# Altium schematic sheet Logic.SchDoc(Logic)
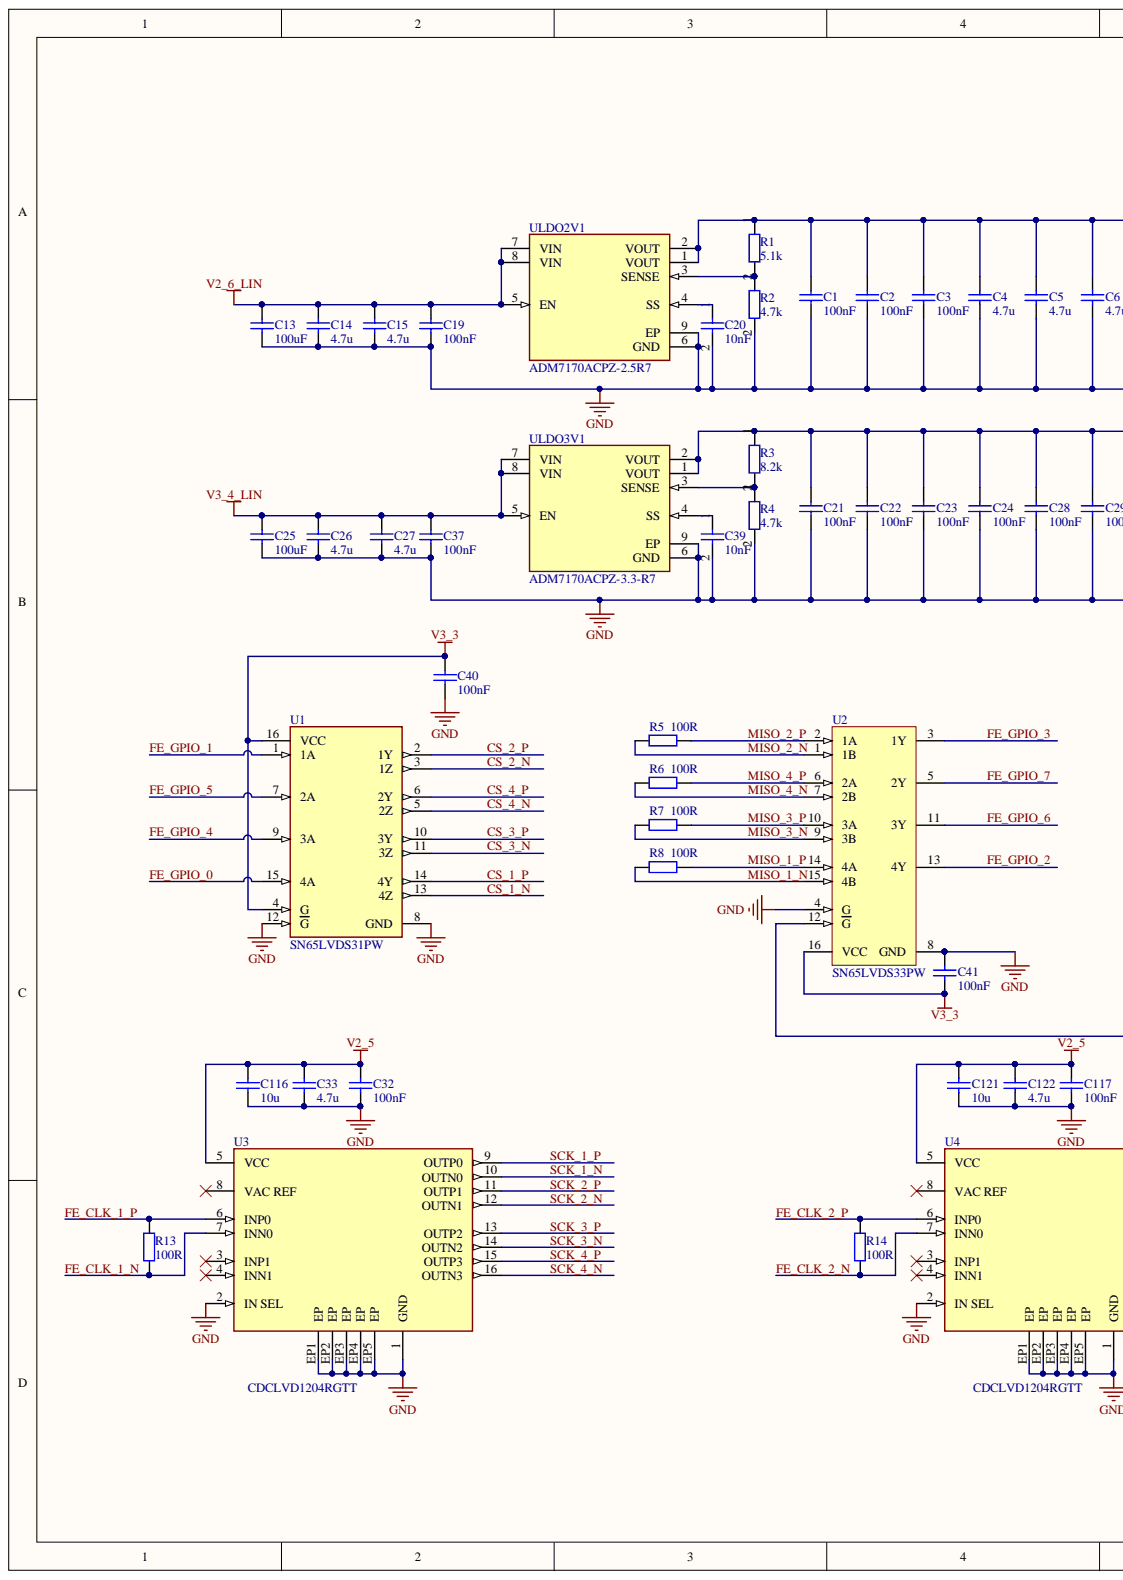
[diagram p0: Logic.SchDoc, left]
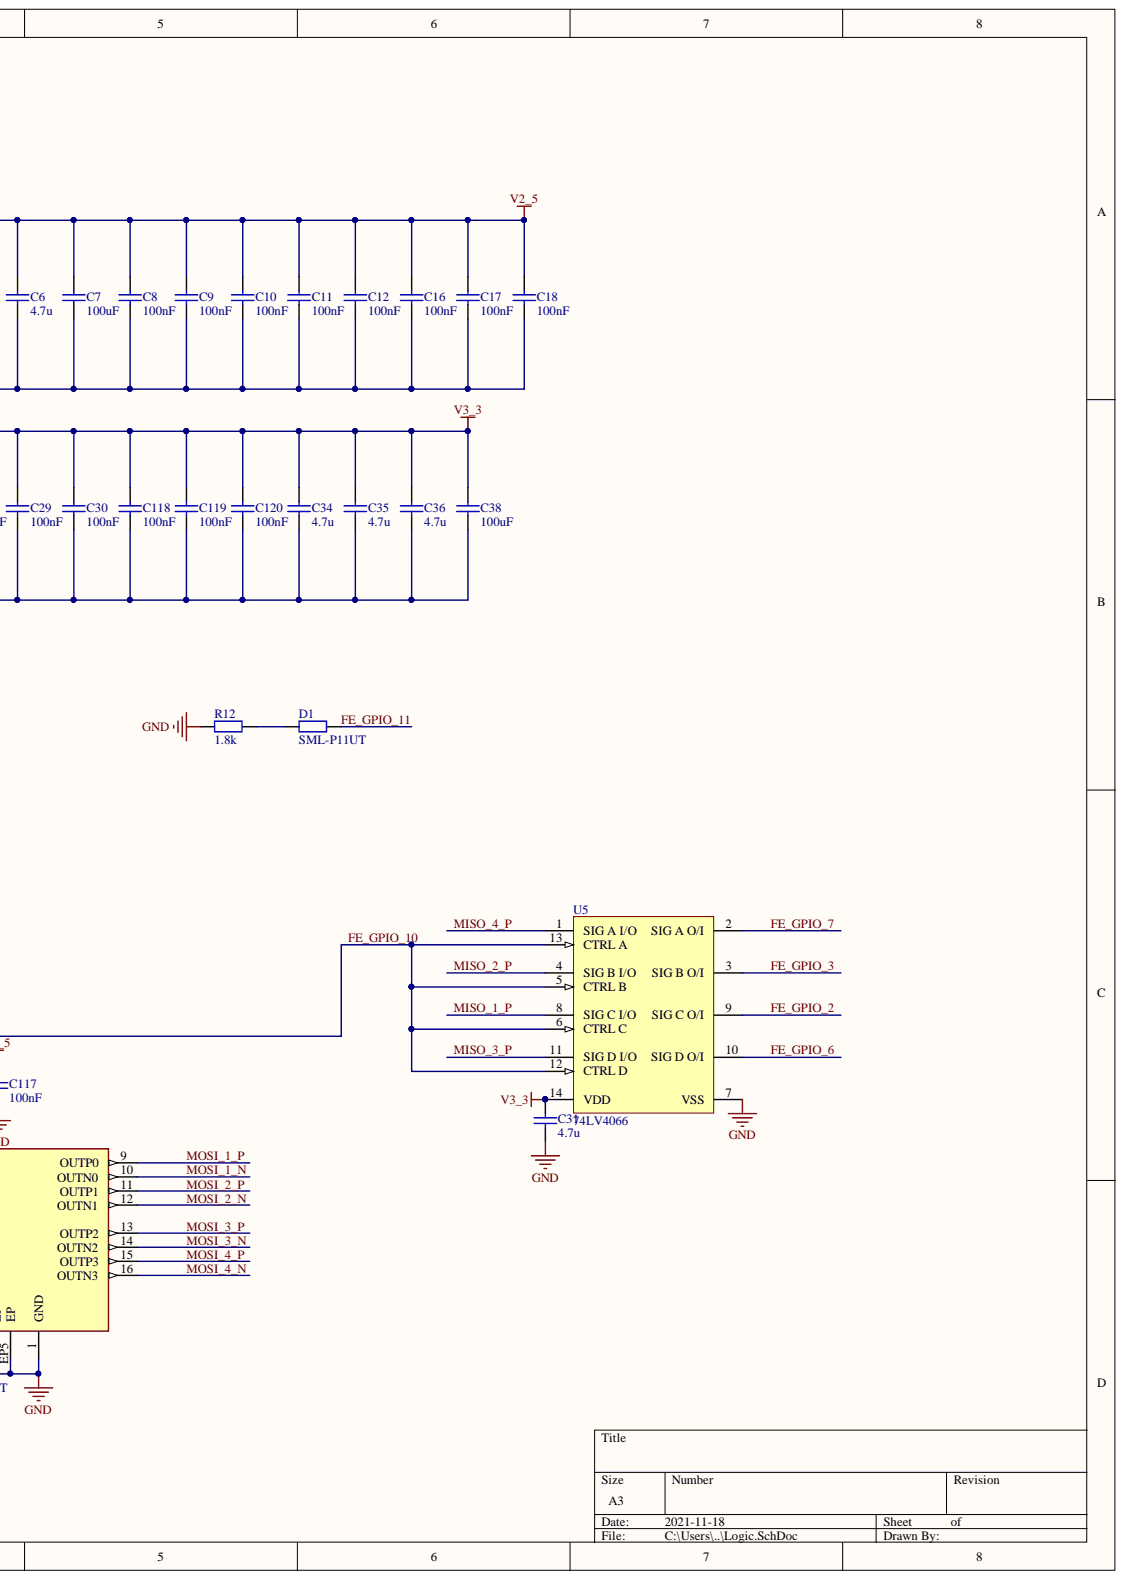
[diagram p1: Logic.SchDoc, right]

## Parts
C1  value 100nF  [C-0402-C]  (hidden comment: Cap)
C2  value 100nF  [C-0402-C]  (hidden comment: Cap)
C3  value 100nF  [C-0402-C]  (hidden comment: Cap)
C4  value 4.7u  [C-0402-C]  (hidden comment: Cap)
C5  value 4.7u  [C-0402-C]  (hidden comment: Cap)
C6  value 4.7u  [C-0402-C]  (hidden comment: Cap)
C7  value 100uF  [C-1206]  (hidden comment: Cap)
C8  value 100nF  [C-0402-C]  (hidden comment: Cap)
C9  value 100nF  [C-0402-C]  (hidden comment: Cap)
C10  value 100nF  [C-0402-C]  (hidden comment: Cap)
C11  value 100nF  [C-0402-C]  (hidden comment: Cap)
C12  value 100nF  [C-0402-C]  (hidden comment: Cap)
C13  value 100uF  [C-1206]  (hidden comment: Cap)
C14  value 4.7u  [C-0402-C]  (hidden comment: Cap)
C15  value 4.7u  [C-0402-C]  (hidden comment: Cap)
C16  value 100nF  [C-0402-C]  (hidden comment: Cap)
C17  value 100nF  [C-0402-C]  (hidden comment: Cap)
C18  value 100nF  [C-0402-C]  (hidden comment: Cap)
C19  value 100nF  [C-0402-C]  (hidden comment: Cap)
C20  value 10nF  [C-0402-C]  (hidden comment: Cap)
C21  value 100nF  [C-0402-C]  (hidden comment: Cap)
C22  value 100nF  [C-0402-C]  (hidden comment: Cap)
C23  value 100nF  [C-0402-C]  (hidden comment: Cap)
C24  value 100nF  [C-0402-C]  (hidden comment: Cap)
C25  value 100uF  [C-1206]  (hidden comment: Cap)
C26  value 4.7u  [C-0402-C]  (hidden comment: Cap)
C27  value 4.7u  [C-0402-C]  (hidden comment: Cap)
C28  value 100nF  [C-0402-C]  (hidden comment: Cap)
C29  value 100nF  [C-0402-C]  (hidden comment: Cap)
C30  value 100nF  [C-0402-C]  (hidden comment: Cap)
C31  value 4.7u  [C-0402-C]  (hidden comment: Cap)
C32  value 100nF  [C-0402-C]  (hidden comment: Cap)
C33  value 4.7u  [C-0402-C]  (hidden comment: Cap)
C34  value 4.7u  [C-0402-C]  (hidden comment: Cap)
C35  value 4.7u  [C-0402-C]  (hidden comment: Cap)
C36  value 4.7u  [C-0402-C]  (hidden comment: Cap)
C37  value 100nF  [C-0402-C]  (hidden comment: Cap)
C38  value 100uF  [C-1206]  (hidden comment: Cap)
C39  value 10nF  [C-0402-C]  (hidden comment: Cap)
C40  value 100nF  [C-0402-C]  (hidden comment: Cap)
C41  value 100nF  [C-0402-C]  (hidden comment: Cap)
C116  value 10u  [C-0805]  (hidden comment: Cap)
C117  value 100nF  [C-0402-C]  (hidden comment: Cap)
C118  value 100nF  [C-0402-C]  (hidden comment: Cap)
C119  value 100nF  [C-0402-C]  (hidden comment: Cap)
C120  value 100nF  [C-0402-C]  (hidden comment: Cap)
C121  value 10u  [C-0805]  (hidden comment: Cap)
C122  value 4.7u  [C-0402-C]  (hidden comment: Cap)
D1  value SML-P11UT  [D-0402]  (hidden comment: Res2)
R1  value 5.1k  [R-0402-C]  (hidden comment: Res2)
R2  value 4.7k  [R-0402-C]  (hidden comment: Res2)
R3  value 8.2k  [R-0402-C]  (hidden comment: Res2)
R4  value 4.7k  [R-0402-C]  (hidden comment: Res2)
R5  value 100R  [R-0402-C]  (hidden comment: Res2)
R6  value 100R  [R-0402-C]  (hidden comment: Res2)
R7  value 100R  [R-0402-C]  (hidden comment: Res2)
R8  value 100R  [R-0402-C]  (hidden comment: Res2)
R12  value 1.8k  [R-0402-C]  (hidden comment: Res2)
R13  value 100R  [R-0402-C]  (hidden comment: Res2)
R14  value 100R  [R-0402-C]  (hidden comment: Res2)
U1  SN65LVDS31PW  [TI-TSSOP16PW-RM065]
U2  SN65LVDS33PW  [TI-TSSOP16PW-RM065]
U3  CDCLVD1204RGTT  [TI-SPVQFN-N16]
U4  CDCLVD1204RGTT  [TI-SPVQFN-N16]
U5  74LV4066  [D0014A_N]
ULDO2V1  ADM7170ACPZ-2.5R7  [LFCSP_WD-8]
ULDO3V1  ADM7170ACPZ-3.3-R7  [LFCSP_WD-8]

## Nets
GND: C1.1 C2.1 C3.1 C4.2 C5.2 C6.2 C7.2 C8.1 C9.1 C10.1 C11.1 C12.1 C13.2 C14.2 C15.2 C16.1 C17.1 C18.1 C19.1 C20.2 C21.1 C22.1 C23.1 C24.1 C25.2 C26.2 C27.2 C28.1 C29.1 C30.1 C31.2 C32.2 C33.2 C34.2 C35.2 C36.2 C37.1 C38.2 C39.2 C40.1 C41.1 C116.2 C117.2 C118.1 C119.1 C120.1 C121.2 C122.2 R2.2 R4.2 R12.1 U1.8(GND) U1.12(G) U2.4(G) U2.8(GND) U3.1(GND) U3.2(IN_SEL) U3.EP1(EP) U3.EP2(EP) U3.EP3(EP) U3.EP4(EP) U3.EP5(EP) U4.1(GND) U4.2(IN_SEL) U4.EP1(EP) U4.EP2(EP) U4.EP3(EP) U4.EP4(EP) U4.EP5(EP) U5.7(VSS) ULDO2V1.6(GND) ULDO2V1.9(EP) ULDO3V1.6(GND) ULDO3V1.9(EP)
V2_5: C1.2 C2.2 C3.2 C4.1 C5.1 C6.1 C7.1 C8.2 C9.2 C10.2 C11.2 C12.2 C16.2 C17.2 C18.2 C32.1 C33.1 C116.1 C117.1 C121.1 C122.1 R1.1 U3.5(VCC) U4.5(VCC) ULDO2V1.1(VOUT) ULDO2V1.2(VOUT)
V2_6_LIN: C13.1 C14.1 C15.1 C19.2 ULDO2V1.5(EN) ULDO2V1.7(VIN) ULDO2V1.8(VIN)
V3_3: C21.2 C22.2 C23.2 C24.2 C28.2 C29.2 C30.2 C31.1 C34.1 C35.1 C36.1 C38.1 C40.2 C41.2 C118.2 C119.2 C120.2 R3.1 U1.4(G) U1.16(VCC) U2.16(VCC) U5.14(VDD) ULDO3V1.1(VOUT) ULDO3V1.2(VOUT)
V3_4_LIN: C25.1 C26.1 C27.1 C37.2 ULDO3V1.5(EN) ULDO3V1.7(VIN) ULDO3V1.8(VIN)
CS_1_N: U1.13(4Z)
CS_1_P: U1.14(4Y)
CS_2_N: U1.3(1Z)
CS_2_P: U1.2(1Y)
CS_3_N: U1.11(3Z)
CS_3_P: U1.10(3Y)
CS_4_N: U1.5(2Z)
CS_4_P: U1.6(2Y)
FE_CLK_1_N: R13.2 U3.7(INN0)
FE_CLK_1_P: R13.1 U3.6(INP0)
FE_CLK_2_N: R14.2 U4.7(INN0)
FE_CLK_2_P: R14.1 U4.6(INP0)
FE_GPIO_0: U1.15(4A)
FE_GPIO_1: U1.1(1A)
FE_GPIO_2: U2.13(4Y) U5.9(SIG_C_O/I)
FE_GPIO_3: U2.3(1Y) U5.3(SIG_B_O/I)
FE_GPIO_4: U1.9(3A)
FE_GPIO_5: U1.7(2A)
FE_GPIO_6: U2.11(3Y) U5.10(SIG_D_O/I)
FE_GPIO_7: U2.5(2Y) U5.2(SIG_A_O/I)
FE_GPIO_10: U2.12(G) U5.5(CTRL_B) U5.6(CTRL_C) U5.12(CTRL_D) U5.13(CTRL_A)
FE_GPIO_11: D1.2
MISO_1_N: R8.2 U2.15(4B)
MISO_1_P: R8.1 U2.14(4A) U5.8(SIG_C_I/O)
MISO_2_N: R5.2 U2.1(1B)
MISO_2_P: R5.1 U2.2(1A) U5.4(SIG_B_I/O)
MISO_3_N: R7.2 U2.9(3B)
MISO_3_P: R7.1 U2.10(3A) U5.11(SIG_D_I/O)
MISO_4_N: R6.2 U2.7(2B)
MISO_4_P: R6.1 U2.6(2A) U5.1(SIG_A_I/O)
MOSI_1_N: U4.10(OUTN0)
MOSI_1_P: U4.9(OUTP0)
MOSI_2_N: U4.12(OUTN1)
MOSI_2_P: U4.11(OUTP1)
MOSI_3_N: U4.14(OUTN2)
MOSI_3_P: U4.13(OUTP2)
MOSI_4_N: U4.16(OUTN3)
MOSI_4_P: U4.15(OUTP3)
SCK_1_N: U3.10(OUTN0)
SCK_1_P: U3.9(OUTP0)
SCK_2_N: U3.12(OUTN1)
SCK_2_P: U3.11(OUTP1)
SCK_3_N: U3.14(OUTN2)
SCK_3_P: U3.13(OUTP2)
SCK_4_N: U3.16(OUTN3)
SCK_4_P: U3.15(OUTP3)
NetC20_1: C20.1 ULDO2V1.4(SS)
NetC39_1: C39.1 ULDO3V1.4(SS)
NetD1_1: D1.1 R12.2
NetR1_2: R1.2 R2.1 ULDO2V1.3(SENSE)
NetR3_2: R3.2 R4.1 ULDO3V1.3(SENSE)

Not connected: U3 3(INP1) 4(INN1) 8(VAC_REF); U4 3(INP1) 4(INN1) 8(VAC_REF)

## Library data of the parts
C1-C41 C116-C122 = Cap: Capacitor; Simulation: CAP; Signal Integrity: Cap; Published: 8-Jun-2000; PackageReference: RAD-0.3; Library Name: Miscellaneous Devices.IntLib; LatestRevisionNote: Re-released for DXP Platform.; LatestRevisionDate: 17-Jul-2002; Component Kind: Standard; Publisher: Altium Limited
D1 R1-R8 R12-R14 = Res2: Resistor; Simulation: RESISTOR; Signal Integrity: Res; Published: 8-Jun-2000; PackageReference: AXIAL-0.4; Library Name: Miscellaneous Devices.IntLib; LatestRevisionNote: Re-released for DXP Platform.; LatestRevisionDate: 17-Jul-2002; Component Kind: Standard; Publisher: Altium Limited
U1 = 09a5448c349e7054202f7f2033ada6b: IC DRIVER 4/0 16SOIC; Turn-On Delay Time: 4.5ns; Operating Supply Voltage: 3.3V; Operating Supply Current: 35mA; Number of Receivers: 4; Min Supply Voltage: 3V; Max Supply Voltage: 3.6V; Max Power Dissipation: 950mW; Max Output Voltage: 454mV; Max Input Current: 20uA; Data Rate: 400Mbps; Width: 3.91mm; Weight: 0.004998oz; Series: 65LVDS; RoHS Compliant: Yes; REACH SVHC: No SVHC; Radiation Hardening: No; Propagation Delay: 4.5ns; Packaging: Rail/Tube; Package Quantity: 40; Number of Pins: 16; Number of Elements: 4; Number of Drivers: 4; Mount: Surface Mount; Min Operating Temperature: -40°C; Max Operating Temperature: 85°C; Length: 9.9mm; Lead Free: Lead Free; Input Current: 35mA; Height: 1.58mm; Contact Plating: Gold; Component Kind: Standard; Case/Package: SOIC; Library Name: ADDON_LIB_SCH.SchLib
U2 = TI-SN65LVDS33-EP-SOIC_D-16: 400 Mbps Enhanced Product High Speed Differential Receivers with CMOS, ECL, LVCMOS, LVDS, LVECL, LVPECL, PECL Input and LVTTL Output, 4 Rx, -55 to +125 degC, 16-pin SOIC (D), Green (RoHS & no Sb/Br); PartNumber: SN65LVDS33MDREP; Part-to-Part Skew(Max)(ps): 1200; Manufacturer: Texas Instruments; SubFamily: LVDS PHY (<800Mbps); Signaling Rate(Mbps): 400; No. of Rx: 4; ICC(Max)(mA): 25; ESD HBM(kV): 15; PackageVersion: revM, Jun-2011; PackageReference: D16; PackageDescription: 16-Pin Plastic Small Outline Integrated Circuit, 9.9 x 3.9 mm, Pitch 1.27 mm Pitch; Output Signal: LVTTL; Mounting Technology: Surface Mount; Input Signal: CMOS, ECL, LVCMOS, LVDS, LVECL, LVPECL, PECL; Function: Receiver; Eco-Plan: Green (RoHS & no Sb/Br); DatasheetVersion: SGLS309B; ComponentLink2URL: SamplesURL:http://www.ti.com/product/sn65lvds33-ep#samples; ComponentLink2Description: URL Link1; ComponentLink1URL: http://www.ti.com/general/docs/lit/getliterature.tsp?genericPartNumber=SN65LVDS33-EP&fileType=pdf; ComponentLink1Description: Datasheet; Component Kind: Standard; Code_JEDEC: MS-012AC; Library Name: ADDON_LIB_SCH.SchLib
U3 U4 = CMP-04855-000015-1: IC CLK BUFFER 2:4 800MHZ 16QFN; Manufacturer: Texas Instruments; Turn-On Delay Time: 2.5ns; Supply Current: 60mA; Operating Supply Voltage: 2.5V; Number of Outputs: 4; Number of Drivers: 2; Number of Circuits: 1; Mode of Operation: DIFFERENTIAL; Min Supply Voltage: 2.375V; Max Supply Voltage: 2.625V; Interface: LVDS; Input: LVCMOS; Width: 3.15mm; Weight: 0.00085oz; Series: CDCLVD1204; REACH SVHC: No SVHC; Radiation Hardening: No; Propagation Delay: 2.5ns; Packaging: Tape and Reel; Package Quantity: 250; Operating Supply Current: 60mA; Number of Pins: 16; Nominal Supply Current: 60mA; Mount: Surface Mount; Min Operating Temperature: -40°C; Max Operating Temperature: 85°C; Max Frequency: 800MHz; Manufacturer Part Number: CDCLVD1204RGTT; Length: 3.15mm; Lead Free: Lead Free; Height: 0.95mm; Frequency: 800MHz; Contact Plating: Gold; Component Kind: Standard; Case/Package: QFN; Library Name: ADDON_LIB_SCH.SchLib
U5 = CMP-1574-00001-1: CMOS Quad Bilateral Switch, D0014A, LARGE T&R; PartNumber: CD4066BM96G4; Part Number: CD4066B; VCC(Min)(V): 3; VCC(Max)(V): 18; Ron(Typ)(Ohms): 125; Ron(Max)(Ohms): 240; Rating: Military; ICC(Typ)(mA): 0.00002; Crosstalk: Yes; Configuration: 4 X SPST (NO); Bandwidth(Max)(MHz): 40; PackageVersion: http://www.ti.com/lit/ml/mpds177g/mpds177g.pdf; PackageReference: D0014A; Output Type: CMOS; Operating Temperature Range(C): -55 to 125; Library Name: Altium Content Vault; DatasheetVersion: SCHS051D; ComponentLink2URL: http://www.ti.com/lit/ds/symlink/cd4066b.pdf; ComponentLink2Description: Datasheet; ComponentLink1URL: http://www.ti.com/; ComponentLink1Description: Manufacturer URL; Component Kind: Standard; Code_IPC: MS-012
ULDO2V1 = 986e13541eed0ecf13a683749f96f81: IC REG LINEAR 3.3V 500MA 8LFCSP; Manufacturer Part Number: ADM7170ACPZ-3.3-R7; Manufacturer: Analog Devices; Standoff Height: 0.02mm; Output Type: Fixed; Number Of Outputs: 1; Nominal Supply Current: 0.7-3mA; Min Supply Voltage: 2.3V; Max Supply Voltage: 6.5V; Max Junction Temp: +125°C; Dropout Voltage: 42mV; Accuracy Percentage: 0.75%; Temperature Range Low: -40°C; Temperature Range High: +125°C; Temperature: -40°C to +125°C; RoHS: TRUE; Package: CP-8-21; Output Voltage: 3.3V; Output Current: 500mA; Octopart: https://octopart.com/adm7170acpz-3.3-r7-analog+devices-49227324; Mouser Description: LDO Voltage Regulators 0.5A Hi PSRR FT LDO 3.3Vo; Lead Free: Yes; Height: 0.8mm; Digikey Description: IC REG LINEAR 3.3V 500MA 8LFCSP; Device Class L3: Voltage Regulators - Linear; Device Class L2: Power Management ICs; Device Class L1: Integrated Circuits (ICs); ComponentLink2URL: https://www.analog.com/media/en/technical-documentation/data-sheets/ADM7170.pdf; ComponentLink2Description: Datasheet; Component Kind: Standard; Category: IC; Automotive: No; Library Name: ADDON_LIB_SCH.SchLib
ULDO3V1 = 986e13541eed0ecf13a683749f96f81: IC REG LINEAR 3.3V 500MA 8LFCSP; Manufacturer: Analog Devices; Standoff Height: 0.02mm; Output Type: Fixed; Number Of Outputs: 1; Nominal Supply Current: 0.7-3mA; Min Supply Voltage: 2.3V; Max Supply Voltage: 6.5V; Max Junction Temp: +125°C; Dropout Voltage: 42mV; Accuracy Percentage: 0.75%; Temperature Range Low: -40°C; Temperature Range High: +125°C; Temperature: -40°C to +125°C; RoHS: TRUE; Package: CP-8-21; Output Voltage: 3.3V; Output Current: 500mA; Octopart: https://octopart.com/adm7170acpz-3.3-r7-analog+devices-49227324; Mouser Description: LDO Voltage Regulators 0.5A Hi PSRR FT LDO 3.3Vo; Manufacturer Part Number: ADM7170ACPZ-3.3-R7; Lead Free: Yes; Height: 0.8mm; Digikey Description: IC REG LINEAR 3.3V 500MA 8LFCSP; Device Class L3: Voltage Regulators - Linear; Device Class L2: Power Management ICs; Device Class L1: Integrated Circuits (ICs); ComponentLink2URL: https://www.analog.com/media/en/technical-documentation/data-sheets/ADM7170.pdf; ComponentLink2Description: Datasheet; Component Kind: Standard; Category: IC; Automotive: No; Library Name: ADDON_LIB_SCH.SchLib
